# Altium schematic sheet EEG.SchDoc
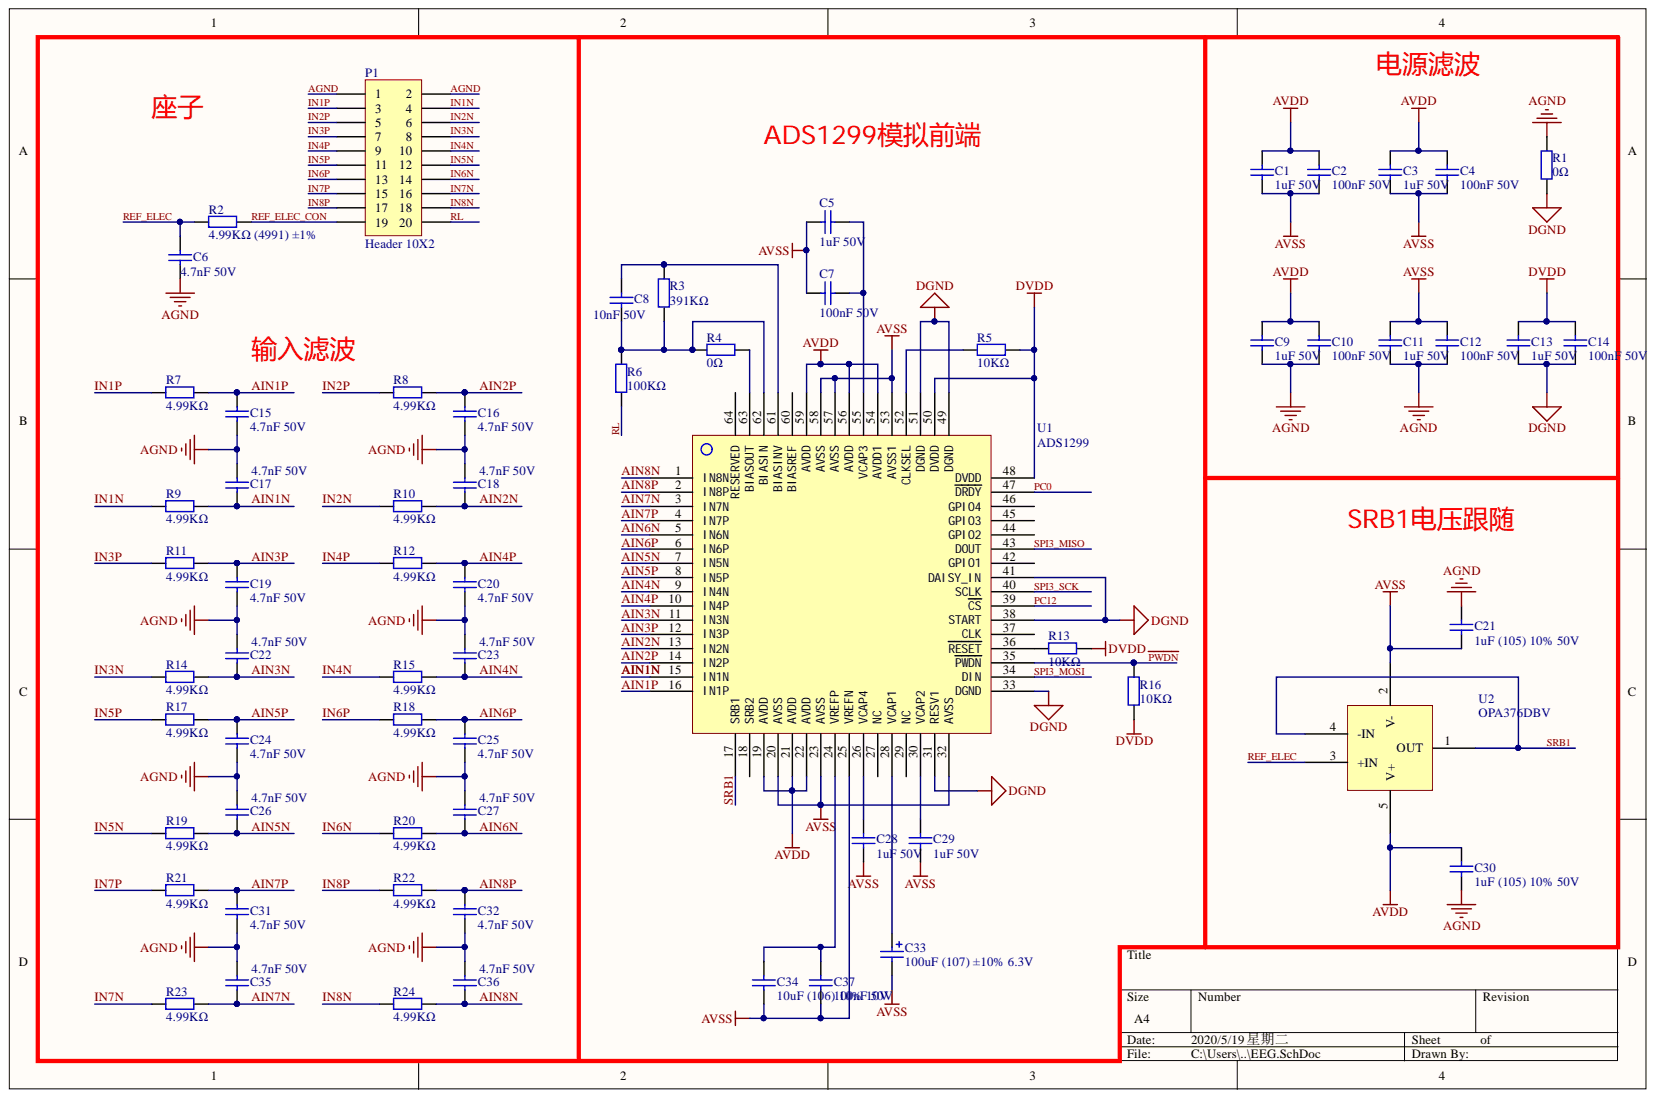
[diagram p0: EEG.SchDoc]

## Parts
C1  1uF 50V  [0603_C]
C2  100nF 50V  [0603_C]
C3  1uF 50V  [0603_C]
C4  100nF 50V  [0603_C]
C5  1uF 50V  [0603_C]
C6  4.7nF 50V  [0603_C]
C7  100nF 50V  [0603_C]
C8  10nF 50V  [0603_C]
C9  1uF 50V  [0603_C]
C10  100nF 50V  [0603_C]
C11  1uF 50V  [0603_C]
C12  100nF 50V  [0603_C]
C13  1uF 50V  [0603_C]
C14  100nF 50V  [0603_C]
C15  4.7nF 50V  [0603_C]
C16  4.7nF 50V  [0603_C]
C17  4.7nF 50V  [0603_C]
C18  4.7nF 50V  [0603_C]
C19  4.7nF 50V  [0603_C]
C20  4.7nF 50V  [0603_C]
C21  1uF (105) 10% 50V  [0603_C]
C22  4.7nF 50V  [0603_C]
C23  4.7nF 50V  [0603_C]
C24  4.7nF 50V  [0603_C]
C25  4.7nF 50V  [0603_C]
C26  4.7nF 50V  [0603_C]
C27  4.7nF 50V  [0603_C]
C28  1uF 50V  [0603_C]
C29  1uF 50V  [0603_C]
C30  1uF (105) 10% 50V  [0603_C]
C31  4.7nF 50V  [0603_C]
C32  4.7nF 50V  [0603_C]
C33  100uF (107) ±10% 6.3V  [CASE-B_3528]
C34  10uF (106) 10% 10V  [0603_C]
C35  4.7nF 50V  [0603_C]
C36  4.7nF 50V  [0603_C]
C37  100nF 50V  [0603_C]
P1  Header 10X2  [HDR2X10]
R1  0Ω  [0603_R]
R2  4.99KΩ (4991) ±1%  [0603_R]
R3  391KΩ  [0603_R]
R4  0Ω  [0603_R]
R5  10KΩ  [0603_R]
R6  100KΩ  [0603_R]
R7  4.99KΩ  [0603_R]
R8  4.99KΩ  [0603_R]
R9  4.99KΩ  [0603_R]
R10  4.99KΩ  [0603_R]
R11  4.99KΩ  [0603_R]
R12  4.99KΩ  [0603_R]
R13  10KΩ  [0603_R]
R14  4.99KΩ  [0603_R]
R15  4.99KΩ  [0603_R]
R16  10KΩ  [0603_R]
R17  4.99KΩ  [0603_R]
R18  4.99KΩ  [0603_R]
R19  4.99KΩ  [0603_R]
R20  4.99KΩ  [0603_R]
R21  4.99KΩ  [0603_R]
R22  4.99KΩ  [0603_R]
R23  4.99KΩ  [0603_R]
R24  4.99KΩ  [0603_R]
U1  ADS1299  [ADS1299]
U2  OPA376DBV  [SOT-23-5]

## Nets
AVDD: C1.1 C2.1 C3.1 C4.1 C9.1 C10.1 C30.2 U1.19(AVDD) U1.21(AVDD) U1.22(AVDD) U1.54(AVDD1) U1.56(AVDD) U1.59(AVDD) U2.5(V+)
AVSS: C1.2 C2.2 C3.2 C4.2 C5.2 C7.2 C11.1 C12.1 C21.1 C28.2 C29.2 C33.2 C34.1 C37.2 U1.20(AVSS) U1.23(AVSS) U1.25(VREFN) U1.32(AVSS) U1.53(AVSS1) U1.57(AVSS) U1.58(AVSS) U2.2(V-)
DGND: C13.2 C14.2 R1.1 U1.31(RESV1) U1.33(DGND) U1.38(START) U1.41(DAISY_IN) U1.49(DGND) U1.51(DGND)
DVDD: C13.1 C14.1 R5.1 R13.1 R16.2 U1.48(DVDD) U1.50(DVDD)
AGND: C6.1 C9.2 C10.2 C11.2 C12.2 C15.1 C16.1 C17.2 C18.2 C19.1 C20.1 C21.2 C22.2 C23.2 C24.1 C25.1 C26.2 C27.2 C30.1 C31.1 C32.1 C35.2 C36.2 P1.1 P1.2 R1.2
AIN1N: C17.1 R9.2 U1.15(IN1N)
AIN1P: C15.2 R7.2 U1.16(IN1P)
AIN2N: C18.1 R10.2 U1.13(IN2N)
AIN2P: C16.2 R8.2 U1.14(IN2P)
AIN3N: C22.1 R14.2 U1.11(IN3N)
AIN3P: C19.2 R11.2 U1.12(IN3P)
AIN4N: C23.1 R15.2 U1.9(IN4N)
AIN4P: C20.2 R12.2 U1.10(IN4P)
AIN5N: C26.1 R19.2 U1.7(IN5N)
AIN5P: C24.2 R17.2 U1.8(IN5P)
AIN6N: C27.1 R20.2 U1.5(IN6N)
AIN6P: C25.2 R18.2 U1.6(IN6P)
AIN7N: C35.1 R23.2 U1.3(IN7N)
AIN7P: C31.2 R21.2 U1.4(IN7P)
AIN8N: C36.1 R24.2 U1.1(IN8N)
AIN8P: C32.2 R22.2 U1.2(IN8P)
IN1N: P1.4 R9.1
IN1P: P1.3 R7.1
IN2N: P1.6 R10.1
IN2P: P1.5 R8.1
IN3N: P1.8 R14.1
IN3P: P1.7 R11.1
IN4N: P1.10 R15.1
IN4P: P1.9 R12.1
IN5N: P1.12 R19.1
IN5P: P1.11 R17.1
IN6N: P1.14 R20.1
IN6P: P1.13 R18.1
IN7N: P1.16 R23.1
IN7P: P1.15 R21.1
IN8N: P1.18 R24.1
IN8P: P1.17 R22.1
~{PWDN}: R16.1 U1.35(PWDN)
PC0: U1.47(DRDY)
PC12: U1.39(CS)
REF_ELEC: C6.2 R2.1 U2.3(+IN)
REF_ELEC_CON: P1.19 R2.2
RL: P1.20 R6.1
SPI3_MISO: U1.43(DOUT)
SPI3_MOSI: U1.34(DIN)
SPI3_SCK: U1.40(SCLK)
SRB1: U1.17(SRB1) U2.1(OUT) U2.4(-IN)
NetC5_1: C5.1 C7.1 U1.55(VCAP3)
NetC8_1: C8.1 R3.1 R4.1 R6.2 U1.62(BIASIN)
NetC8_2: C8.2 R3.2 U1.61(BIASINV)
NetC28_1: C28.1 U1.26(VCAP4)
NetC29_1: C29.1 U1.30(VCAP2)
NetC33_1: C33.1 U1.28(VCAP1)
NetC34_2: C34.2 C37.1 U1.24(VREFP)
NetR4_2: R4.2 U1.63(BIASOUT)
NetR5_2: R5.2 U1.52(CLKSEL)
NetR13_2: R13.2 U1.36(RESET)

Not connected: U1 18(SRB2) 27(NC) 29(NC) 37(CLK) 42(GPIO1) 44(GPIO2) 45(GPIO3) 46(GPIO4) 60(BIASREF) 64(RESERVED)

## Library data of the parts
C1-C5 C7-C14 C28 C29 C37 = 0603 10nF (103) 10% 50V: 贴片电容; Component Kind: Standard; ComponentLink1Description: 供应商链接; ComponentLink1URL: http://www.szlcsc.com/product/details_58143.html; Library Name: Miscellaneous Devices LC.IntLib; Package: 0603; Supplier: LC; SuppliersPartNumber: C57112
C6 C15-C20 C22-C27 C31 C32 C35 C36 = 0603 4.7nF (472) 10% 50V: 贴片电容; Component Kind: Standard; ComponentLink1Description: 供应商链接; ComponentLink1URL: http://www.szlcsc.com/product/details_55004.html; Library Name: Miscellaneous Devices LC.IntLib; Package: 0603; Supplier: LC; SuppliersPartNumber: C53987
C21 C30 = 0603 1uF (105) 10% 50V: 贴片电容; Component Kind: Standard; ComponentLink1Description: 供应商链接; ComponentLink1URL: http://www.szlcsc.com/product/details_16531.html; Library Name: Miscellaneous Devices LC.IntLib; Package: 0603; Supplier: LC; SuppliersPartNumber: C15849
C33 = 3528 100uF (107) 10% 6.3V: 钽电容; Component Kind: Standard; ComponentLink1Description: 供应商链接; ComponentLink1URL: http://www.szlcsc.com/product/details_16815.html; Library Name: Miscellaneous Devices LC.IntLib; Package: 3528; Supplier: LC; SuppliersPartNumber: C16133
C34 = 0603 10uF (106) 10% 10V: 贴片电容; Component Kind: Standard; ComponentLink1Description: 供应商链接; ComponentLink1URL: http://www.szlcsc.com/product/details_20411.html; Library Name: Miscellaneous Devices LC.IntLib; Package: 0603; Supplier: LC; SuppliersPartNumber: C19702
P1 = Header 10X2: Header, 10-Pin, Dual row; Component Kind: Standard; LatestRevisionDate: 17-Jul-2002; LatestRevisionNote: Re-released for DXP Platform.; Library Name: Miscellaneous Connectors.IntLib; Signal Integrity: Connector; Publisher: Altium Limited
R1 R3 R4 R6 = 0603 100KΩ (1003) 1%: 贴片电阻; Component Kind: Standard; ComponentLink1Description: 供应商链接; ComponentLink1URL: http://www.szlcsc.com/product/details_26546.html; Library Name: Miscellaneous Devices LC.IntLib; Package: 0603; Supplier: LC; SuppliersPartNumber: C25803
R2 R7-R12 R14 R15 R17-R24 = 0603 4.99KΩ (4991) 1%: 贴片电阻; Component Kind: Standard; ComponentLink1Description: 供应商链接; ComponentLink1URL: http://www.szlcsc.com/product/details_23773.html; Library Name: Miscellaneous Devices LC.IntLib; Package: 0603; Supplier: LC; SuppliersPartNumber: C23046
R5 R13 R16 = 0603 10KΩ (1002) 1%: 贴片电阻; Component Kind: Standard; ComponentLink1Description: 供应商链接; ComponentLink1URL: http://www.szlcsc.com/product/details_26547.html; Library Name: Miscellaneous Devices LC.IntLib; Package: 0603; Supplier: LC; SuppliersPartNumber: C25804
U1 = ADS1299: Component Kind: Standard; Library Name: EEG.SchLib
U2 = OPA376DBV: Component Kind: Standard; Library Name: EEG.SchLib
